# Altium schematic sheet MainBoard_KONZEVIC.SchDoc
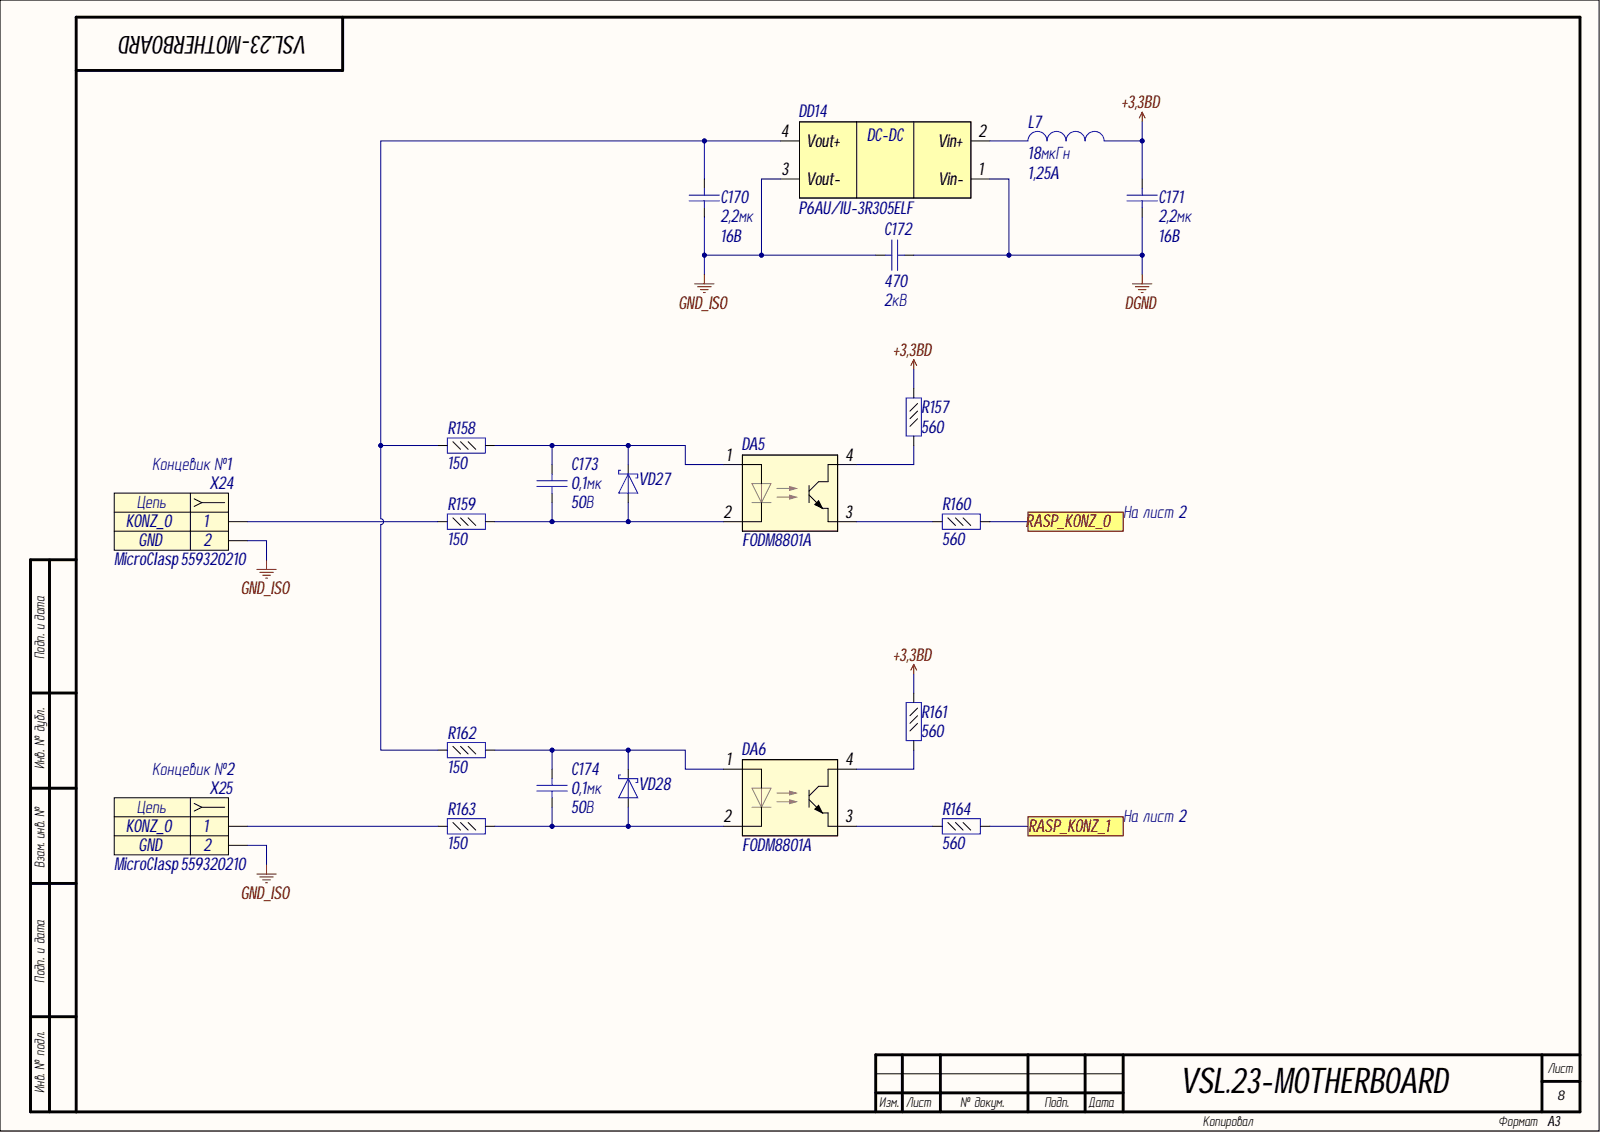
[diagram p0: MainBoard_KONZEVIC.SchDoc]

## Parts
C170  2,2мк  Voltage: 16B  [C0603]
C171  2,2мк  Voltage: 16B  [C0603]
C172  value 470  Voltage: 2кВ  [C1206]  (hidden comment: Capacitor)
C173  value 0,1мк  Voltage: 50В  [C0402]  (hidden comment: Capacitor)
C174  value 0,1мк  Voltage: 50В  [C0402]  (hidden comment: Capacitor)
DA5  OPTO-1  [Miniflat4]  (value not drawn)
DA6  OPTO-1  [Miniflat4]  (value not drawn)
DD14  Part Number: P6AU/IU-3R305ELF  [SIP4]  (hidden comment: P6AU-xxxxELF)
L7  value 18мкГн  Rated Current: 1,25A  [SDR0604]  (hidden comment: Inductor)
R157  value 560  [R0402]  (hidden comment: Resistor_0,062Вт)
R158  value 150  [R0402]  (hidden comment: Resistor_0,062Вт)
R159  value 150  [R0402]  (hidden comment: Resistor_0,062Вт)
R160  value 560  [R0402]  (hidden comment: Resistor_0,062Вт)
R161  value 560  [R0402]  (hidden comment: Resistor_0,062Вт)
R162  value 150  [R0402]  (hidden comment: Resistor_0,062Вт)
R163  value 150  [R0402]  (hidden comment: Resistor_0,062Вт)
R164  value 560  [R0402]  (hidden comment: Resistor_0,062Вт)
VD27  DiodeSchottky  [SOD-123]  (value not drawn)
VD28  DiodeSchottky  [SOD-123]  (value not drawn)
X24  Концевик №1  Contact_1: KONZ_0  Contact_2: GND  Part Name: MicroClasp  Part Number: 559320210  [MOLEX_559320210]
X25  Концевик №2  Contact_1: KONZ_0  Contact_2: GND  Part Name: MicroClasp  Part Number: 559320210  [MOLEX_559320210]

## Ports
RASP_KONZ_0, RASP_KONZ_1

## Nets
+3,3BD: C171.2 L7.1 R157.1 R161.1
DGND: C171.1 C172.1 DD14.1(Vin-)
GND_ISO: C170.1 C172.2 DD14.3(Vout-) X24.2 X25.2
RASP_KONZ_0: R160.2 ; port RASP_KONZ_0
RASP_KONZ_1: R164.2 ; port RASP_KONZ_1
NetC170_2: C170.2 DD14.4(Vout+) R158.1 R162.1
NetC173_1: C173.1 DA5.2 R159.2 VD27.2
NetC173_2: C173.2 DA5.1 R158.2 VD27.1
NetC174_1: C174.1 DA6.2 R163.2 VD28.2
NetC174_2: C174.2 DA6.1 R162.2 VD28.1
NetDA5_3: DA5.3 R160.1
NetDA5_4: DA5.4 R157.2
NetDA6_3: DA6.3 R164.1
NetDA6_4: DA6.4 R161.2
NetDD14_2: DD14.2(Vin+) L7.2
NetR159_1: R159.1 X24.1
NetR163_1: R163.1 X25.1

## Library data of the parts
C170 C171 = cap287: Конденсатор; Manufacture: Murata; Part Name: Ceramic; Part Number: GRM188Z71C225ME43J; Database Table Name: Capacitors$; tga: 0,10%; TKE: X7R; Component Kind: Standard; id: cap287; Library Name: MOP44.DbLib; Value: 2,2мк
C172 = cap284: Конденсатор; Manufacture: Yageo; Part Name: Ceramic; Part Number: CC1206KKX7RDBB471; Database Table Name: Capacitors$; TKE: X7R; Component Kind: Standard; id: cap284; Library Name: MOP44.DbLib
C173 C174 = cap277: Конденсатор; Manufacture: Murata; Part Name: Ceramic; Part Number: GRM155R71H104KE14D; Database Table Name: Capacitors$; tga: 0,10%; TKE: X7R; Component Kind: Standard; id: cap277; Library Name: MOP44.DbLib
DA5 DA6 = FODM8801A: Опторазвязка; Manufacturer: ON Semiconductor; Continuous collector current: 30mA; Database Table Name: Optocoupler$; Forward current: 20мА; Forward Voltage: 1,8B; id: opto2; Max tempreture: +125; Min tempreture: -40; Power dissipation: 300mW; Reverse voltage: 6B; Voltage coll-emmiter: 75B; Component Kind: Standard; Library Name: MOP44.DbLib; Part Number: FODM8801A
DD14 = pwr54: DC/DC преобразователь; Manufacturer: PEAK Electronics; Part Name: DC/DC Converter Isolated; Current: 200mA; Database Table Name: PowerTransducer$; Input Voltage: 3,3B; Output Voltage: 5B; Component Kind: Standard; id: pwr54; Library Name: MOP44.DbLib; Type: Isolated
L7 = ind45: EMI Suppression Filter; Manufacture: Bourns; Part Name: SMD Power Inductors; Part Number: SDR0604- 180YL; Database Table Name: Inductor$; Resistance: 0,15Ohm; Saturation Current: 1,5A; Component Kind: Standard; id: ind45; Library Name: MOP44.DbLib
R157 R160 R161 R164 = res264: Резистор; Manufacture: Yageo; Part Number: RC0402FR-07560RL; Accuracy: 0,01; Database Table Name: Resistor$; Component Kind: Standard; id: res264; Library Name: MOP44.DbLib; Part Name: Resistor; Power: 0,062Вт
R158 R159 R162 R163 = res250: Резистор; Manufacture: Yageo; Part Number: RC0402FR-07150RL; Accuracy: 0,01; Database Table Name: Resistor$; Component Kind: Standard; id: res250; Library Name: MOP44.DbLib; Part Name: Resistor; Power: 0,062Вт
VD27 VD28 = diode27: Диод Шоттки; Manufacture: ON Semiconductor; Part Number: MBR2H100SFT3G; Breakdown Current: 0,5mA; Breakdown Voltage: 100B; Database Table Name: Diode$; Forward Current: 2А; Number of Channels: 1; Component Kind: Standard; id: diode27; Library Name: MOP44.DbLib; Max Reverse Voltage: 100B; Part Name: Diode Schottky
X24 X25 = connect95: Разъем - 2 конт. розетка; Manufacture: Molex; Database Table Name: Connector$; Etch: 2mm; Component Kind: Standard; id: connect95; Library Name: MOP44.DbLib
